# Altium schematic sheet MCU.SchDoc(MCU)
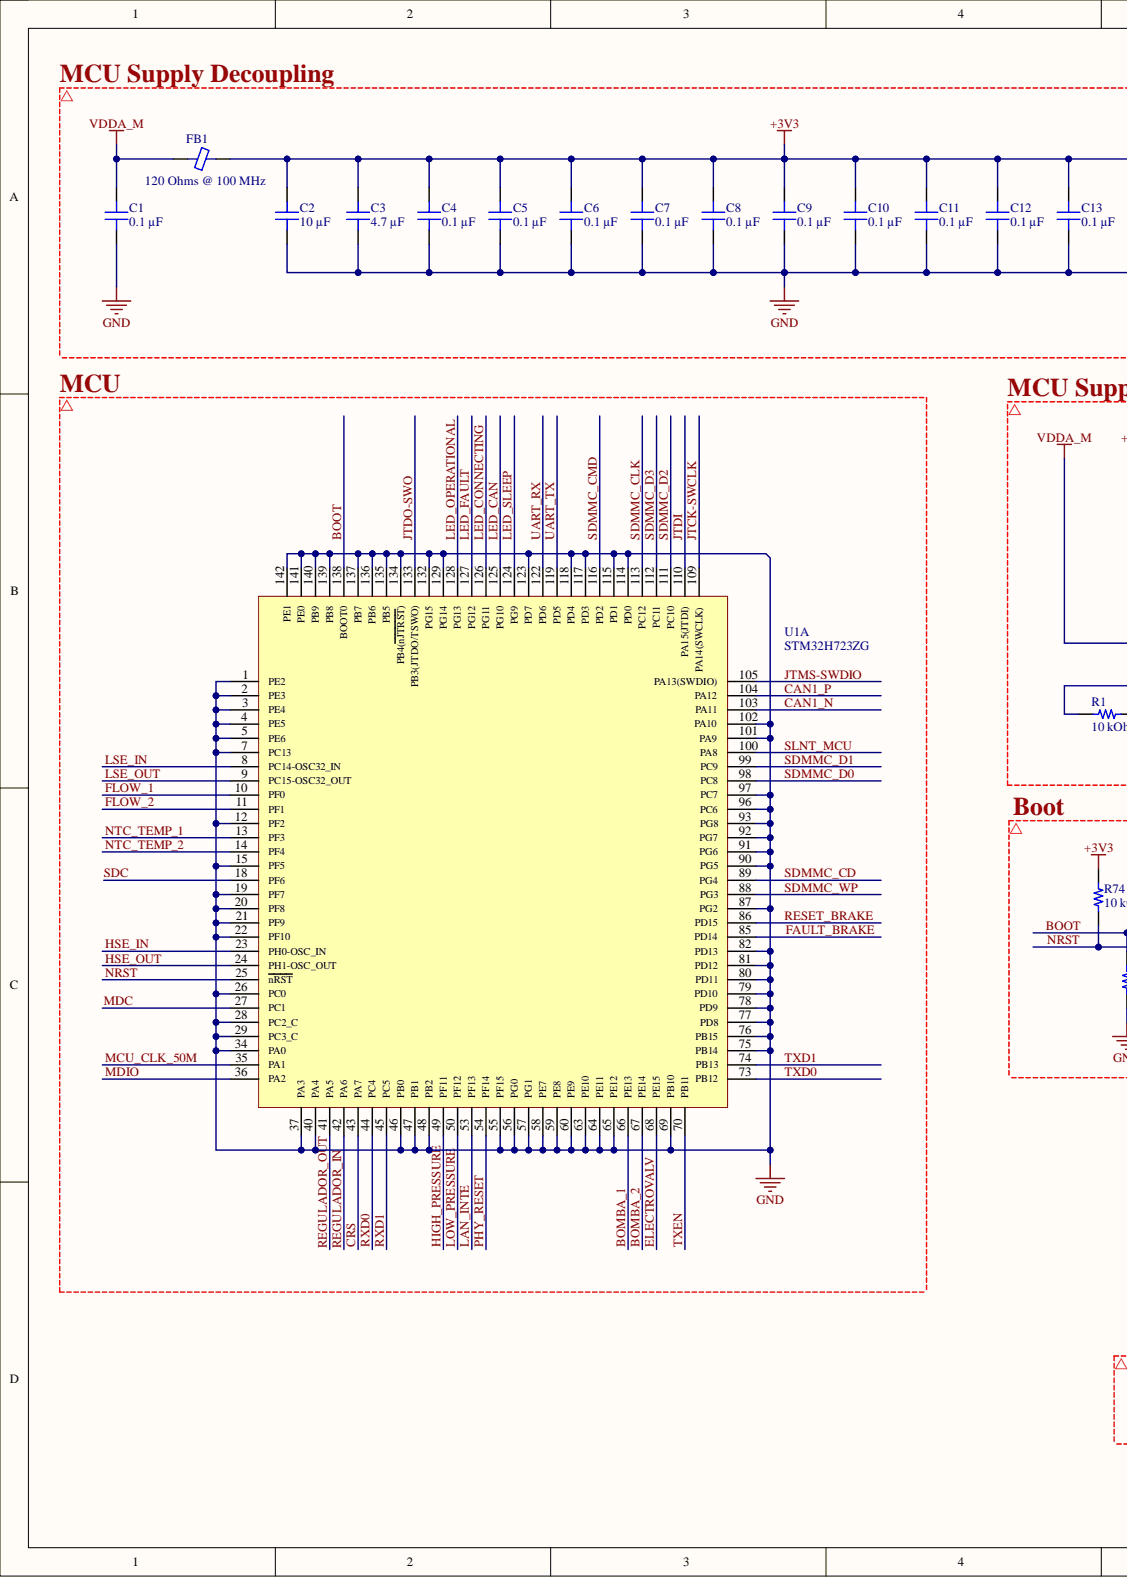
[diagram p0: MCU.SchDoc, left]
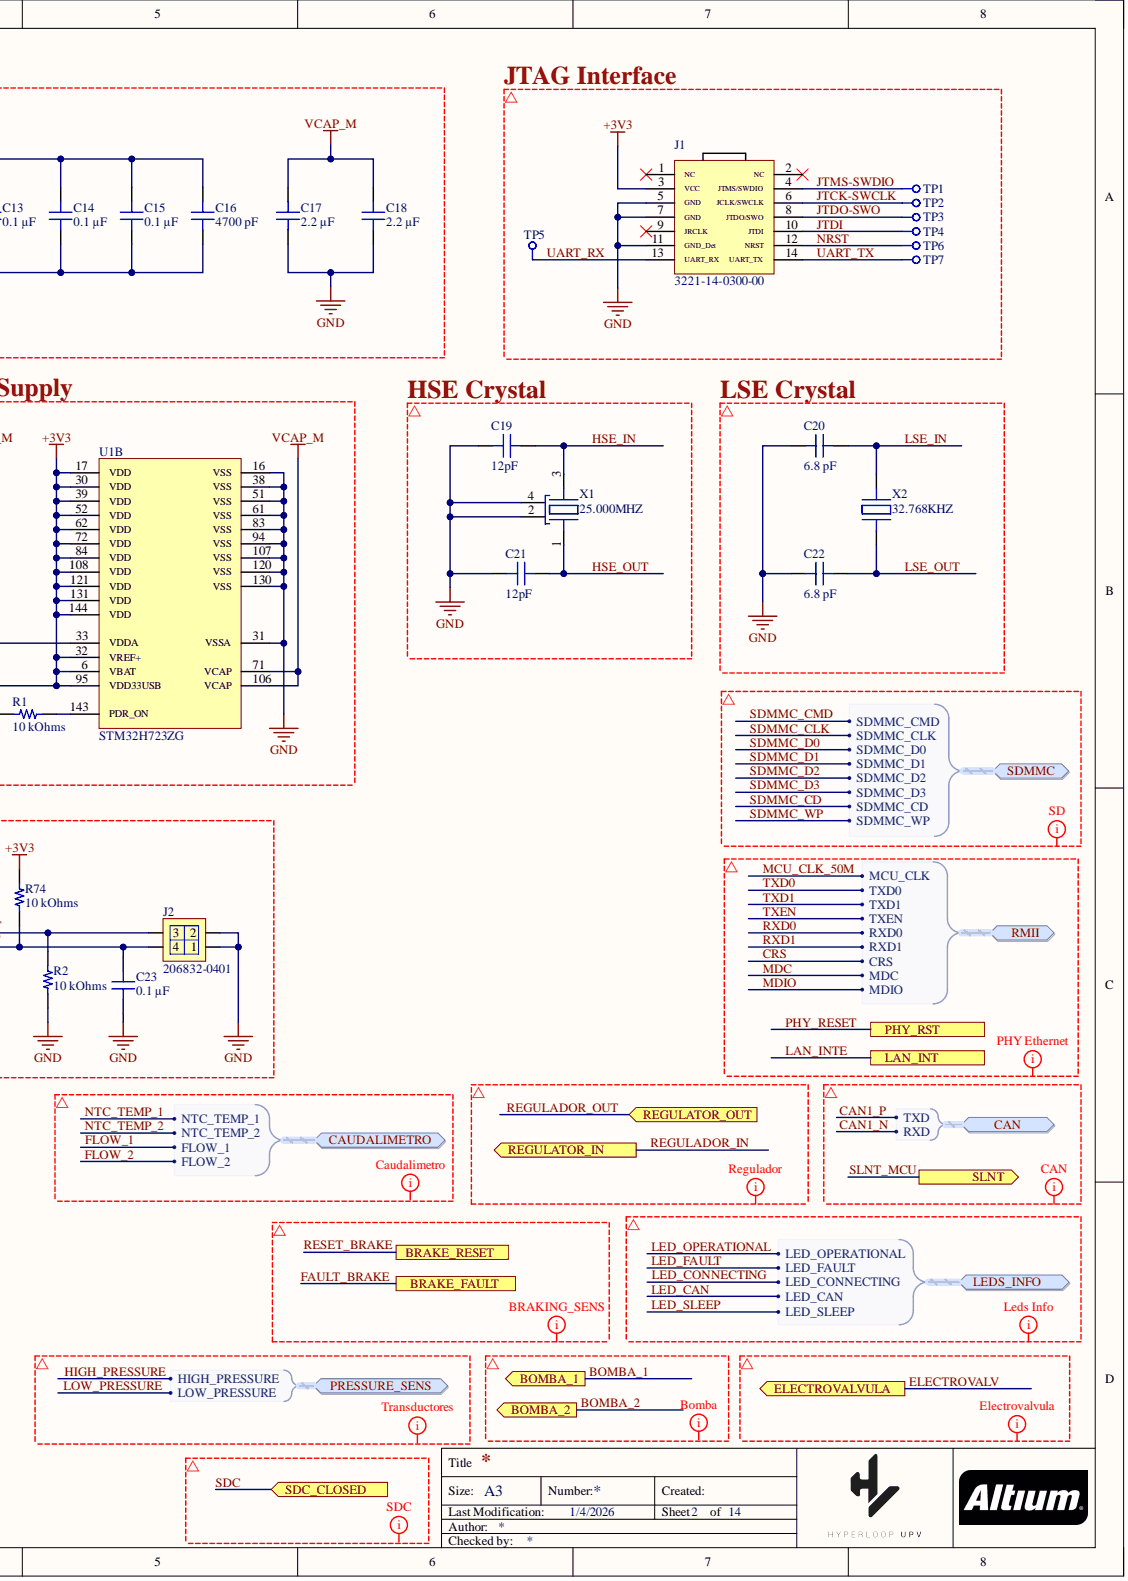
[diagram p1: MCU.SchDoc, right]

## Parts
C1  0.1 µF
C2  10 µF
C3  4.7 µF
C4  0.1 µF
C5  0.1 µF
C6  0.1 µF
C7  0.1 µF
C8  0.1 µF
C9  0.1 µF
C10  0.1 µF
C11  0.1 µF
C12  0.1 µF
C13  0.1 µF
C14  0.1 µF
C15  0.1 µF
C16  4700 pF
C17  2.2 µF
C18  2.2 µF
C19  12pF
C20  6.8 pF
C21  12pF
C22  6.8 pF
C23  0.1 µF
FB1  120 Ohms @ 100 MHz
J1  3221-14-0300-00
J2  206832-0401
R1  10 kOhms
R2  10 kOhms
R74  10 kOhms
TP1  Test point 1.2mm  (value not drawn)
TP2  Test point 1.2mm  (value not drawn)
TP3  Test point 1.2mm  (value not drawn)
TP4  Test point 1.2mm  (value not drawn)
TP5  Test point 1.2mm  (value not drawn)
TP6  Test point 1.2mm  (value not drawn)
TP7  Test point 1.2mm  (value not drawn)
U1  STM32H723ZG  (parts A,B)
X1  25.000MHZ
X2  32.768KHZ

## Ports
BOMBA_1, BOMBA_2, BRAKE_FAULT, BRAKE_RESET, CAN, CAN.RXD, CAN.TXD, CAUDALIMETRO, CAUDALIMETRO.FLOW_1, CAUDALIMETRO.FLOW_2, CAUDALIMETRO.NTC_TEMP_1, CAUDALIMETRO.NTC_TEMP_2, ELECTROVALVULA, LAN_INT, LEDS_INFO, LEDS_INFO.LED_CAN, LEDS_INFO.LED_CONNECTING, LEDS_INFO.LED_FAULT, LEDS_INFO.LED_OPERATIONAL, LEDS_INFO.LED_SLEEP, PHY_RST, PRESSURE_SENS, PRESSURE_SENS.HIGH_PRESSURE, PRESSURE_SENS.LOW_PRESSURE, REGULATOR_IN, REGULATOR_OUT, RMII, RMII.CRS, RMII.MCU_CLK, RMII.MDC, RMII.MDIO, RMII.RXD0, RMII.RXD1, RMII.TXD0, RMII.TXD1, RMII.TXEN, SDC_CLOSED, SDMMC, SDMMC.SDMMC_CD, SDMMC.SDMMC_CLK, SDMMC.SDMMC_CMD, SDMMC.SDMMC_D0, SDMMC.SDMMC_D1, SDMMC.SDMMC_D2, SDMMC.SDMMC_D3, SDMMC.SDMMC_WP, SLNT

## Nets
+3V3: C2.2 C3.2 C4.2 C5.2 C6.2 C7.2 C8.2 C9.2 C10.2 C11.2 C12.2 C13.2 C14.2 C15.2 C16.2 FB1.2 J1.3(VCC) R1.2 R74.1 U1.6(VBAT) U1.17(VDD) U1.30(VDD) U1.32(VREF+) U1.39(VDD) U1.52(VDD) U1.62(VDD) U1.72(VDD) U1.84(VDD) U1.95(VDD33USB) U1.108(VDD) U1.121(VDD) U1.131(VDD) U1.144(VDD)
GND: C1.1 C2.1 C3.1 C4.1 C5.1 C6.1 C7.1 C8.1 C9.1 C10.1 C11.1 C12.1 C13.1 C14.1 C15.1 C16.1 C17.1 C18.1 C19.1 C20.1 C21.1 C22.1 C23.1 J1.5(GND) J1.7(GND) J1.11(GND_Det) J2.1 J2.2 R2.2 U1.1(PE2) U1.2(PE3) U1.3(PE4) U1.4(PE5) U1.5(PE6) U1.7(PC13) U1.12(PF2) U1.15(PF5) U1.16(VSS) U1.19(PF7) U1.20(PF8) U1.21(PF9) U1.22(PF10) U1.26(PC0) U1.28(PC2_C) U1.29(PC3_C) U1.31(VSSA) U1.34(PA0) U1.37(PA3) U1.38(VSS) U1.40(PA4) U1.46(PB0) U1.47(PB1) U1.48(PB2) U1.51(VSS) U1.55(PF15) U1.56(PG0) U1.57(PG1) U1.58(PE7) U1.59(PE8) U1.60(PE9) U1.61(VSS) U1.63(PE10) U1.64(PE11) U1.65(PE12) U1.69(PB10) U1.75(PB14) U1.76(PB15) U1.77(PD8) U1.78(PD9) U1.79(PD10) U1.80(PD11) U1.81(PD12) U1.82(PD13) U1.83(VSS) U1.87(PG2) U1.90(PG5) U1.91(PG6) U1.92(PG7) U1.93(PG8) U1.94(VSS) U1.96(PC6) U1.97(PC7) U1.101(PA9) U1.102(PA10) U1.107(VSS) U1.114(PD0) U1.115(PD1) U1.117(PD3) U1.118(PD4) U1.120(VSS) U1.123(PD7) U1.129(PG14) U1.130(VSS) U1.132(PG15) U1.134(PB4(nJTRST)) U1.135(PB5) U1.136(PB6) U1.137(PB7) U1.139(PB8) U1.140(PB9) U1.141(PE0) U1.142(PE1) X1.2 X1.4
VCAP_M: C17.2 C18.2 U1.71(VCAP) U1.106(VCAP)
VDDA_M: C1.2 FB1.1 U1.33(VDDA)
BOMBA_1: U1.66(PE13) ; port BOMBA_1
BOMBA_2: U1.67(PE14) ; port BOMBA_2
BOOT: J2.3 R2.1 U1.138(BOOT0)
CAN1_N: U1.103(PA11)
CAN1_P: U1.104(PA12)
CRS: U1.43(PA7) ; port RMII
ELECTROVALV: U1.68(PE15) ; port ELECTROVALVULA
FAULT_BRAKE: U1.85(PD14) ; port BRAKE_FAULT
FLOW_1: U1.10(PF0) ; port CAUDALIMETRO
FLOW_2: U1.11(PF1) ; port CAUDALIMETRO
HIGH_PRESSURE: U1.49(PF11) ; port PRESSURE_SENS
HSE_IN: C19.2 U1.23(PH0-OSC_IN) X1.3
HSE_OUT: C21.2 U1.24(PH1-OSC_OUT) X1.1
JTCK-SWCLK: J1.6(JCLK/SWCLK) TP2.1 U1.109(PA14(SWCLK))
JTDI: J1.10(JTDI) TP4.1 U1.110(PA15(JTDI))
JTDO-SWO: J1.8(JTDO/SWO) TP3.1 U1.133(PB3(JTDO/TSWO))
JTMS-SWDIO: J1.4(JTMS/SWDIO) TP1.1 U1.105(PA13(SWDIO))
LAN_INTE: U1.53(PF13) ; port LAN_INT
LED_CAN: U1.125(PG10)
LED_CONNECTING: U1.126(PG11)
LED_FAULT: U1.127(PG12)
LED_OPERATIONAL: U1.128(PG13)
LED_SLEEP: U1.124(PG9)
LOW_PRESSURE: U1.50(PF12) ; port PRESSURE_SENS
LSE_IN: C20.2 U1.8(PC14-OSC32_IN) X2.2
LSE_OUT: C22.2 U1.9(PC15-OSC32_OUT) X2.1
MCU_CLK_50M: U1.35(PA1) ; port RMII
MDC: U1.27(PC1) ; port RMII
MDIO: U1.36(PA2) ; port RMII
NRST: C23.2 J1.12(NRST) J2.4 R74.2 TP6.1 U1.25(nRST)
NTC_TEMP_1: U1.13(PF3) ; port CAUDALIMETRO
NTC_TEMP_2: U1.14(PF4) ; port CAUDALIMETRO
PHY_RESET: U1.54(PF14) ; port PHY_RST
REGULADOR_IN: U1.42(PA6) ; port REGULATOR_IN
REGULADOR_OUT: U1.41(PA5) ; port REGULATOR_OUT
RESET_BRAKE: U1.86(PD15) ; port BRAKE_RESET
RXD0: U1.44(PC4) ; port RMII
RXD1: U1.45(PC5) ; port RMII
SDC: U1.18(PF6) ; port SDC_CLOSED
SDMMC_CD: U1.89(PG4) ; port SDMMC
SDMMC_CLK: U1.113(PC12) ; port SDMMC
SDMMC_CMD: U1.116(PD2) ; port SDMMC
SDMMC_D0: U1.98(PC8) ; port SDMMC
SDMMC_D1: U1.99(PC9) ; port SDMMC
SDMMC_D2: U1.111(PC10) ; port SDMMC
SDMMC_D3: U1.112(PC11) ; port SDMMC
SDMMC_WP: U1.88(PG3) ; port SDMMC
SLNT_MCU: U1.100(PA8) ; port SLNT
TXD0: U1.73(PB12) ; port RMII
TXD1: U1.74(PB13) ; port RMII
TXEN: U1.70(PB11) ; port RMII
UART_RX: J1.13(UART_RX) TP5.1 U1.122(PD6)
UART_TX: J1.14(UART_TX) TP7.1 U1.119(PD5)
NetR1_1: R1.1 U1.143(PDR_ON)
N00001: ; port LEDS_INFO
N00003: ; port LEDS_INFO
N00005: ; port LEDS_INFO
N00007: ; port LEDS_INFO
N00009: ; port LEDS_INFO
N00011: ; port CAN
N00012: ; port CAN

Not connected: J1 1(NC) 2(NC) 9(JRCLK)

## Library data of the parts
C1 C4-C15 C23 = CGA3E2X7R1H104K080AE: CAP CER 0.1UF 50V X7R 0603; manufacturer: TDK Corporation; Database Table Name: Capacitors - Ceramic - 0603; Tolerance: ±10%; Applications: Automotive, Boardflex Sensitive; Capacitance: 0.1 µF; Component Kind: Standard; componentlink1description: Datasheet; componentlink1url: https://product.tdk.com/info/en/catalog/datasheets/mlcc_automotive_soft_en.pdf?ref_disty=digikey; componentlink2description: DigiKey Link; componentlink2url: https://www.digikey.com/en/products/detail/tdk-corporation/CGA3E2X7R1H104K080AE/4490594; Device Package: 0603 (1608 Metric); Features: Soft Termination; lastupdated: 19/09/2022 13:01:18; Library Name: Celestial Altium Library - altium_library.DbLib; Lifecycle Status: Active; Minimum Order: 1; Mounting Type: Surface Mount, MLCC; Operating Temperature: -55°C ~ 125°C; Package / Case: 0603 (1608 Metric); packaging: TapeAndReel; Part Number: CGA3E2X7R1H104K080AE; Part Status: Active; partid: 2715; price: 0.01; Ratings: AEC-Q200; series: CGA; Size / Dimension: 0.063" L x 0.031" W (1.60mm x 0.80mm); Supplier 1: DigiKey; Supplier Part Number 1: 445-15957-1-ND; Temperature Coefficient: X7R; Thickness (Max): 0.037" (0.95mm); Value: 0.1 µF; Voltage - Rated: 50V
C2 = CL31B106KBHNNNE: CAP CER 10UF 50V X7R 1206; manufacturer: Samsung Electro-Mechanics; Database Table Name: Capacitors - Ceramic - 1206; Tolerance: ±10%; Applications: General Purpose; Capacitance: 10 µF; Component Kind: Standard; componentlink1description: Datasheet; componentlink1url: http://www.samsungsem.com/kr/support/product-search/mlcc/CL31B106KBHNNNE.jsp; componentlink2description: DigiKey Link; componentlink2url: https://www.digikey.com/en/products/detail/samsung-electro-mechanics/CL31B106KBHNNNE/5961251; Device Package: 1206 (3216 Metric); lastupdated: 19/09/2022 13:06:52; Library Name: Celestial Altium Library - altium_library.DbLib; Lifecycle Status: Active; Minimum Order: 1; Mounting Type: Surface Mount, MLCC; Operating Temperature: -55°C ~ 125°C; Package / Case: 1206 (3216 Metric); packaging: TapeAndReel; Part Number: CL31B106KBHNNNE; Part Status: Active; partid: 40563; price: 0.06; series: CL; Size / Dimension: 0.126" L x 0.063" W (3.20mm x 1.60mm); Supplier 1: DigiKey; Supplier Part Number 1: 1276-6767-1-ND; Temperature Coefficient: X7R; Thickness (Max): 0.071" (1.80mm); Value: 10 µF; Voltage - Rated: 50V
C3 = CL31B475KBHNFNE: CAP CER 4.7UF 50V X7R 1206; manufacturer: Samsung Electro-Mechanics; Database Table Name: Capacitors - Ceramic - 1206; Tolerance: ±10%; Applications: General Purpose; Capacitance: 4.7 µF; Component Kind: Standard; componentlink1description: Datasheet; componentlink1url: http://www.samsungsem.com/kr/support/product-search/mlcc/CL31B475KBHNFNE.jsp; componentlink2description: DigiKey Link; componentlink2url: https://www.digikey.com/en/products/detail/samsung-electro-mechanics/CL31B475KBHNFNE/3888837; Device Package: 1206 (3216 Metric); lastupdated: 19/09/2022 13:06:52; Library Name: Celestial Altium Library - altium_library.DbLib; Lifecycle Status: Active; Minimum Order: 1; Mounting Type: Surface Mount, MLCC; Operating Temperature: -55°C ~ 125°C; Package / Case: 1206 (3216 Metric); packaging: TapeAndReel; Part Number: CL31B475KBHNFNE; Part Status: Active; partid: 40777; price: 0.05; series: CL; Size / Dimension: 0.126" L x 0.063" W (3.20mm x 1.60mm); Supplier 1: DigiKey; Supplier Part Number 1: 1276-3179-1-ND; Temperature Coefficient: X7R; Thickness (Max): 0.071" (1.80mm); Value: 4.7 µF; Voltage - Rated: 50V
C16 = C0603C472K4RECAUTO: CAP CER 0603 4.7NF 16V X7R 10%; manufacturer: KEMET; Database Table Name: Capacitors - Ceramic - 0603; Applications: Automotive, ESD Protection; Capacitance: 4700 pF; Component Kind: Standard; componentlink1description: Datasheet; componentlink1url: https://api.kemet.com/component-edge/download/datasheet/C0603C472K4RECAUTO.pdf; componentlink2description: DigiKey Link; componentlink2url: https://www.digikey.com/en/products/detail/kemet/C0603C472K4RECAUTO/8640473; Device Package: 0603 (1608 Metric); lastupdated: 19/09/2022 13:00:31; Library Name: Celestial Altium Library - altium_library.DbLib; Lifecycle Status: Active; Minimum Order: 1; Mounting Type: Surface Mount, MLCC; Operating Temperature: -55°C ~ 125°C; Package / Case: 0603 (1608 Metric); packaging: TapeAndReel; Part Number: C0603C472K4RECAUTO; Part Status: Active; partid: 17340; price: 0.02; Ratings: AEC-Q200; series: ESD SMD Auto X7R; Size / Dimension: 0.063" L x 0.031" W (1.60mm x 0.80mm); Supplier 1: DigiKey; Supplier Part Number 1: 399-17879-1-ND; Temperature Coefficient: X7R; Thickness (Max): 0.034" (0.87mm); Tolerance: ±10%; Value: 4700 pF; Voltage - Rated: 16V
C17 C18 = CL31B225KBHNFNE: CAP CER 2.2UF 50V X7R 1206; manufacturer: Samsung Electro-Mechanics; Database Table Name: Capacitors - Ceramic - 1206; Tolerance: ±10%; Applications: General Purpose; Capacitance: 2.2 µF; Component Kind: Standard; componentlink1description: Datasheet; componentlink1url: http://www.samsungsem.com/kr/support/product-search/mlcc/CL31B225KBHNFNE.jsp; componentlink2description: DigiKey Link; componentlink2url: https://www.digikey.com/en/products/detail/samsung-electro-mechanics/CL31B225KBHNFNE/3888795; Device Package: 1206 (3216 Metric); lastupdated: 19/09/2022 13:06:35; Library Name: Celestial Altium Library - altium_library.DbLib; Lifecycle Status: Active; Minimum Order: 1; Mounting Type: Surface Mount, MLCC; Operating Temperature: -55°C ~ 125°C; Package / Case: 1206 (3216 Metric); packaging: TapeAndReel; Part Number: CL31B225KBHNFNE; Part Status: Active; partid: 21802; price: 0.04; series: CL; Size / Dimension: 0.126" L x 0.063" W (3.20mm x 1.60mm); Supplier 1: DigiKey; Supplier Part Number 1: 1276-3137-1-ND; Temperature Coefficient: X7R; Thickness (Max): 0.071" (1.80mm); Value: 2.2 µF; Voltage - Rated: 50V
C19 C21 = 06035A120KAT2A: CAP CER 12PF 50V C0G/NP0 0603; manufacturer: AVX Corporation; Database Table Name: Capacitors - Ceramic - 0603; Temperature Coefficient: C0G, NP0; Tolerance: ±10%; Applications: General Purpose; Capacitance: 12pF; Component Kind: Standard; componentlink1description: Datasheet; componentlink1url: http://datasheets.avx.com/C0GNP0-Dielectric.pdf; componentlink2description: DigiKey Link; componentlink2url: http://digikey.com/product-detail/en/avx-corporation/06035A120KAT2A/478-12692-1-ND/9949226; Device Package: 0603 (1608 Metric); lastupdated: 02/09/2020 1:03:16; Library Name: Celestial Altium Library - altium_library.DbLib; Lifecycle Status: Active; Minimum Order: 1; Mounting Type: Surface Mount, MLCC; Operating Temperature: -55°C ~ 125°C; Package / Case: 0603 (1608 Metric); packaging: TapeAndReel; Part Number: 06035A120KAT2A; Part Status: Active; partid: 13375; price: 0.01; series: -; Size / Dimension: 0.063" L x 0.032" W (1.60mm x 0.81mm); Supplier 1: DigiKey; Supplier Part Number 1: 478-12692-1-ND; Thickness (Max): 0.035" (0.90mm); Value: 12pF; Voltage - Rated: 50V
C20 C22 = CC0603CRNPO9BN6R8: CAP CER 6.8PF 50V C0G/NPO 0603; manufacturer: Yageo; Database Table Name: Capacitors - Ceramic - 0603; Temperature Coefficient: C0G, NP0; Tolerance: ±0.25pF; Applications: General Purpose; Capacitance: 6.8 pF; Component Kind: Standard; componentlink1description: Datasheet; componentlink1url: https://www.yageo.com/upload/media/product/productsearch/datasheet/mlcc/UPY-GP_NP0_16V-to-50V_17.pdf; componentlink2description: DigiKey Link; componentlink2url: https://www.digikey.com/en/products/detail/yageo/CC0603CRNPO9BN6R8/5883459; Device Package: 0603 (1608 Metric); lastupdated: 19/09/2022 13:01:00; Library Name: Celestial Altium Library - altium_library.DbLib; Lifecycle Status: Active; Minimum Order: 1; Mounting Type: Surface Mount, MLCC; Operating Temperature: -55°C ~ 125°C; Package / Case: 0603 (1608 Metric); packaging: TapeAndReel; Part Number: CC0603CRNPO9BN6R8; Part Status: Active; partid: 9476; price: 0.01; series: CC; Size / Dimension: 0.063" L x 0.031" W (1.60mm x 0.80mm); Supplier 1: DigiKey; Supplier Part Number 1: 311-3861-1-ND; Thickness (Max): 0.035" (0.90mm); Value: 6.8 pF; Voltage - Rated: 50V
FB1 = BLM21BB121SN1D: FERRITE BEAD 120 OHM 0805 1LN; Manufacturer: Murata Electronics; Current Rating (Max): 600mA; Database Table Name: Filters - Ferrite Beads and Chips; DC Resistance (DCR) (Max): 190mOhm; Filter Type: Signal Line; Number of Lines: 1; Component Kind: Standard; ComponentLink1Description: Datasheet; ComponentLink1URL: https://www.murata.com/en-us/products/en-us/products/productdata/8796738977822/ENFA0005.pdf; ComponentLink2Description: DigiKey Link; ComponentLink2URL: https://www.digikey.com/en/products/detail/murata-electronics/BLM21BB121SN1D/584252; Device Package: 0805 (2012 Metric); Height (Max): 0.041" (1.05mm); Impedance @ Frequency: 120 Ohms @ 100 MHz; LastUpdated: 19/09/2022 13:44:16; Library Name: Celestial Altium Library - altium_library.DbLib; Lifecycle Status: Active; Minimum Order: 1; Mounting Type: Surface Mount; Operating Temperature: -55°C ~ 125°C; Package / Case: 0805 (2012 Metric); Packaging: TapeAndReel; Part Number: BLM21BB121SN1D; Part Status: Active; PartId: 1466; Price: 0.03; Series: EMIFIL®, BLM21; Size / Dimension: 0.079" L x 0.049" W (2.00mm x 1.25mm); Supplier 1: DigiKey; Supplier Part Number 1: 490-1042-1-ND; Value: 120 Ohms @ 100 MHz
J1 = CMP-00031-3: IDC BOX HEADER, 0.050" (1.27MM), 14 POS LOW PROFILE, SMT, NYLON 6T, G/F; Component Kind: Standard; Library Name: Hyperloop UPV
J2 = CMP-006-00301-4: MICRO-FIT Plus Header Assembly Dual Row 4 Position Straight Tin; Connector Type: Micro-Fit+; Gender: Male; Max Current: 12.5 A; Max Voltage: 600V AC (RMS)/DC; Component Kind: Standard; Max Operating Temperature: 105°C; Min Operating Temperature: -40°C; Mounting Technology: THT; Pins: 4; RoHS Compliant: Yes; Library Name: Hyperloop UPV
R1 R2 R74 = RNCS0603BKE10K0: RES 10K OHM 0.1% 1/16W 0603; Manufacturer: Stackpole Electronics Inc; Database Table Name: Resistors - Surface Mount - 0603; Power (Watts): 0.063W, 1/16W; Temperature Coefficient: ±25ppm/°C; Component Kind: Standard; ComponentLink1Description: Datasheet; ComponentLink1URL: https://www.seielect.com/catalog/sei-rncs_rnch.pdf; ComponentLink2Description: DigiKey Link; ComponentLink2URL: https://www.digikey.com/en/products/detail/stackpole-electronics-inc/RNCS0603BKE10K0/1708836; Composition: Thin Film; Device Package: 0603 (1608 Metric); Features: Anti-Corrosive, Automotive AEC-Q200, Moisture Resistant; Height - Seated (Max): 0.022" (0.55mm); LastUpdated: 09-Feb-24 20:43:37; Library Name: Celestial Altium Library - altium_library.DbLib; Lifecycle Status: Active; Minimum Order: 1; Number of Terminations: 2; Operating Temperature: -55°C ~ 155°C; Package / Case: 0603 (1608 Metric); Packaging: TapeAndReel; Part Number: RNCS0603BKE10K0; Part Status: Active; PartId: 4838; Price: 0.06; Ratings: AEC-Q200; Resistance: 10 kOhms; Series: RNCS; Size / Dimension: 0.061" L x 0.031" W (1.55mm x 0.80mm); Supplier 1: DigiKey; Supplier Device Package: 0603; Supplier Part Number 1: RNCS0603BKE10K0CT-ND; Tolerance: ±0.1%; Value: 10 kOhms
TP1-TP7 = CMP-006-00250-2: 1.2mm Test point; Component Kind: Standard; Library Name: Hyperloop UPV
U1 = CMP-020-00000-1: Arm® Cortex®-M7 32-bit 550 MHz MCU, up to 1 MB Flash, 564 KB RAM, Ethernet, USB, 3x FD-CAN, Graphics, 2x 16-bit ADCs; Component Kind: Standard; Library Name: Hyperloop UPV
X1 = CMP-006-00239-1: 25.000 MHz Ultra miniature ceramic glass sealed SMD crystal.; ESR: 60; Frequency: 25MHz; Load Capacitance: 10pF; Component Kind: Standard; ComponentLink1Description: Datasheet; ComponentLink1URL: https://www.mouser.es/datasheet/2/3/ABM8G-1608872.pdf; ComponentLink2Description: Mouser; ComponentLink2URL: https://www.mouser.es/ProductDetail/ABRACON/ABM8G-25000MHZ-18-D2Y-T?qs=3LVMET7lfiOk74SfCQnWgQ%3D%3D&gclid=CjwKCAjwp9qZBhBkEiwAsYFsbyeoz4brcfy0N2dPfJW3HYWQHdhpa7YsCY7xL0acf3omx4fczTcAvRoCykAQAvD_BwE; Max Operating Temperature: 60°C; Min Operating Temperature: -10°C; Mounting Technology: SMT; Pins: 4; RoHS Compliant: YES; Library Name: Hyperloop UPV
X2 = CMP-006-00241-1: 32.768kHz Crystal; ESR: 55k; Load Capacitance: 6pF; Component Kind: Standard; ComponentLink1Description: Datasheet; ComponentLink1URL: https://www.mouser.es/datasheet/2/3/ABS07_120_32_768kHz_T-218607.pdf; ComponentLink2Description: Mouser; ComponentLink2URL: https://www.mouser.es/ProductDetail/ABRACON/ABS07-120-32.768KHZ-T?qs=z9S2%252BbP5pWhyNaQ4AovDCg%3D%3D; Frequency: 32.768kHz; Max Operating Temperature: 85°C; Min Operating Temperature: -40°C; Mounting Technology: SMT; Pins: 2; RoHS Compliant: YES; Library Name: Hyperloop UPV
